# Altium schematic sheet main_microusb.SchDoc(main_microusb)
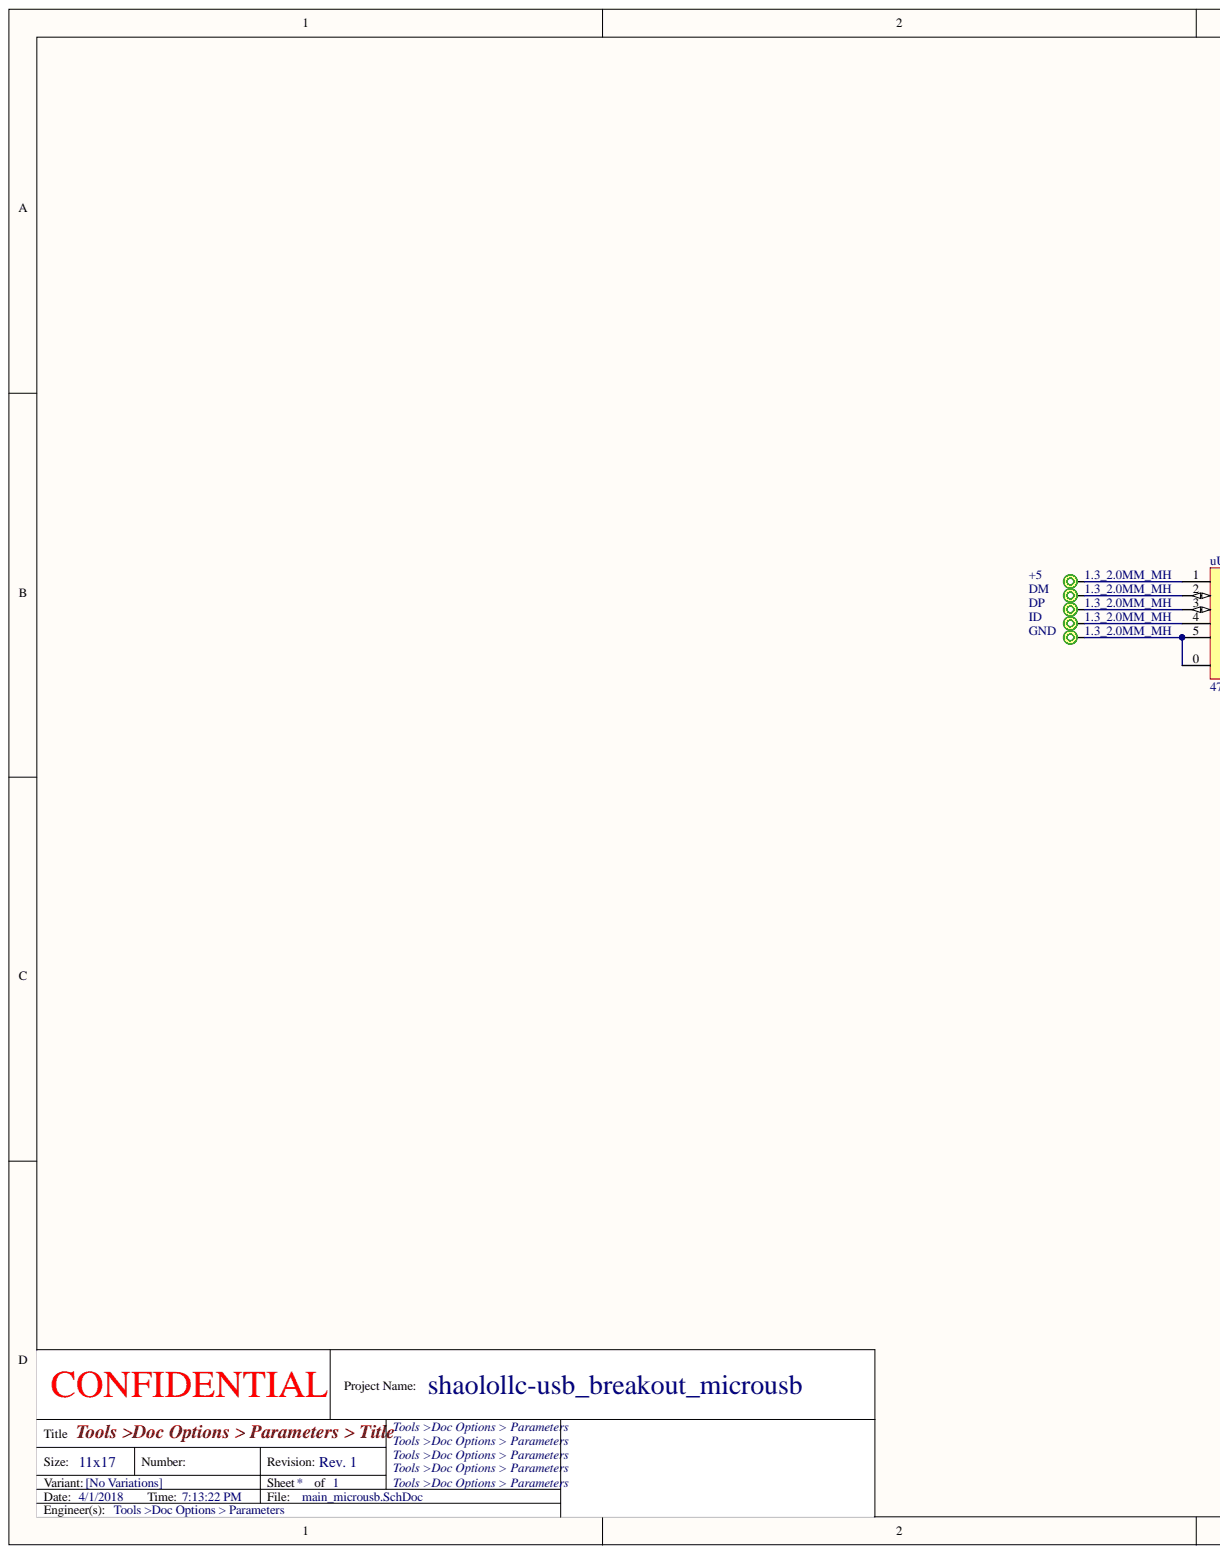
[diagram p0: main_microusb.SchDoc, left]
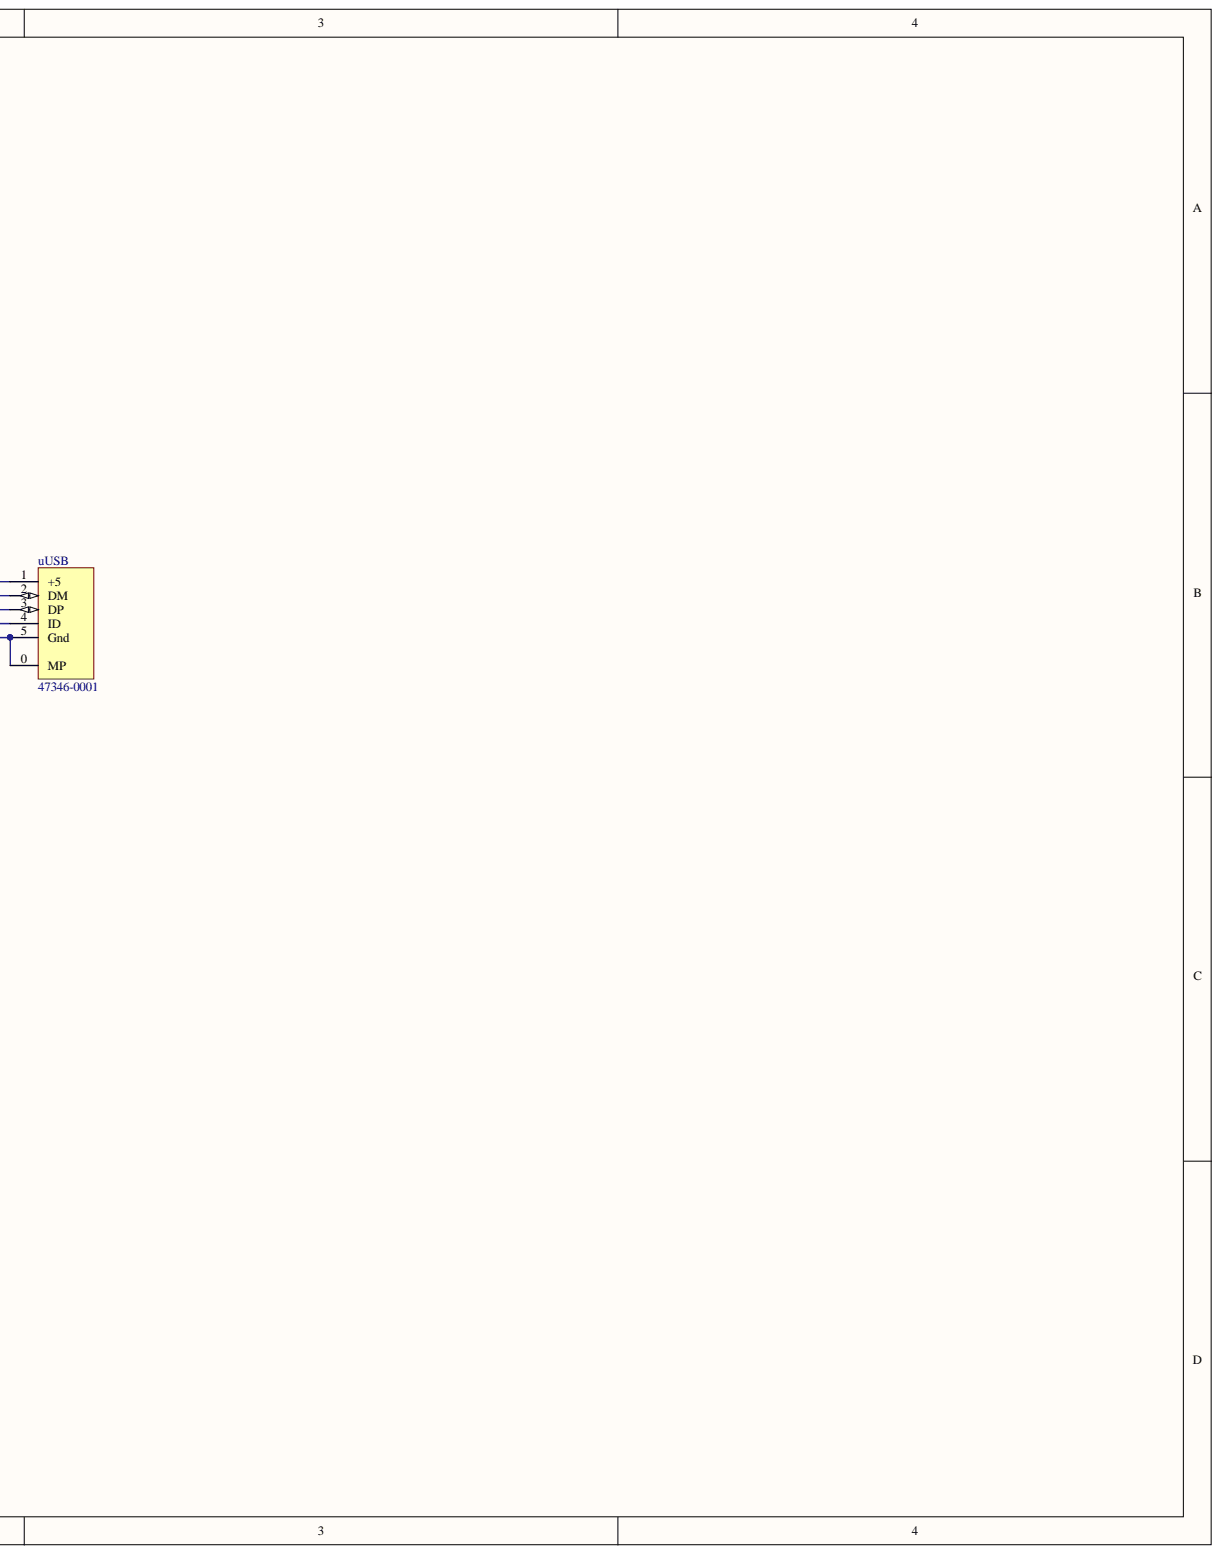
[diagram p1: main_microusb.SchDoc, right]

## Parts
+5  value 1.3_2.0MM_MH  [1.3_2.0MM_PH]  (no BOM)
DM  value 1.3_2.0MM_MH  [1.3_2.0MM_PH]  (no BOM)
DP  value 1.3_2.0MM_MH  [1.3_2.0MM_PH]  (no BOM)
GND  value 1.3_2.0MM_MH  [1.3_2.0MM_PH]  (no BOM)
ID  value 1.3_2.0MM_MH  [1.3_2.0MM_PH]  (no BOM)
uUSB  value 47346-0001  [USB Micro B]

## Nets
Net+5_1: +5.1 uUSB.1(+5)
NetDM_1: DM.1 uUSB.2(DM)
NetDP_1: DP.1 uUSB.3(DP)
NetGND_1: GND.1 uUSB.0(MP) uUSB.5(Gnd)
NetID_1: ID.1 uUSB.4(ID)

## Library data of the parts
+5 DM DP GND ID = PCB_0092: 1.3_2.0MM_MH; Manufacturer Part Number: S46; Manufacturer: Misc PCB; Reviewer: shawn@shaolo.com; Database Table Name: MISC_PCB; UID: 61; Supplier 1: ShaoloLLC; RoHS: True; Published: 1/20/2015; Part Number: PCB_0092; ComponentLink3Description: Package URL; ComponentLink2Description: Datasheet URL; ComponentLink1URL: 0; ComponentLink1Description: Manufacturer URL; Comment: =Comment; ClassName: Plated Holes; Publisher: shawn@shaolo.com; Library Name: Altium Access.DbLib
uUSB = CONN_0056: CONN RCPT 5POS MICRO USB R/A; Manufacturer: Molex; SMT?: False; Reviewer: shawn@shaolo.com; Database Table Name: EMECH_Connector; Conductors: 5; UID: 55; Supplier Part Number 1: WM17141CT-ND; Supplier 1: Digi-Key; RoHS: False; Reflow?: True; Published: 2/16/2010; Part Number: CONN_0056; Orientation: RA; Manufacturer Part Number: 47346-0001; HelpURL: http://www.molex.com/pdm_docs/sd/473460001_sd.pdf; ComponentLink3Description: Package URL; ComponentLink2Description: Datasheet URL; ComponentLink1URL: 0; ComponentLink1Description: Manufacturer URL; Component Kind: Standard; Comment: =Comment; Publisher: shawn@shaolo.com; Library Name: Altium Access.DbLib
